# Altium schematic sheet CAN.SchDoc
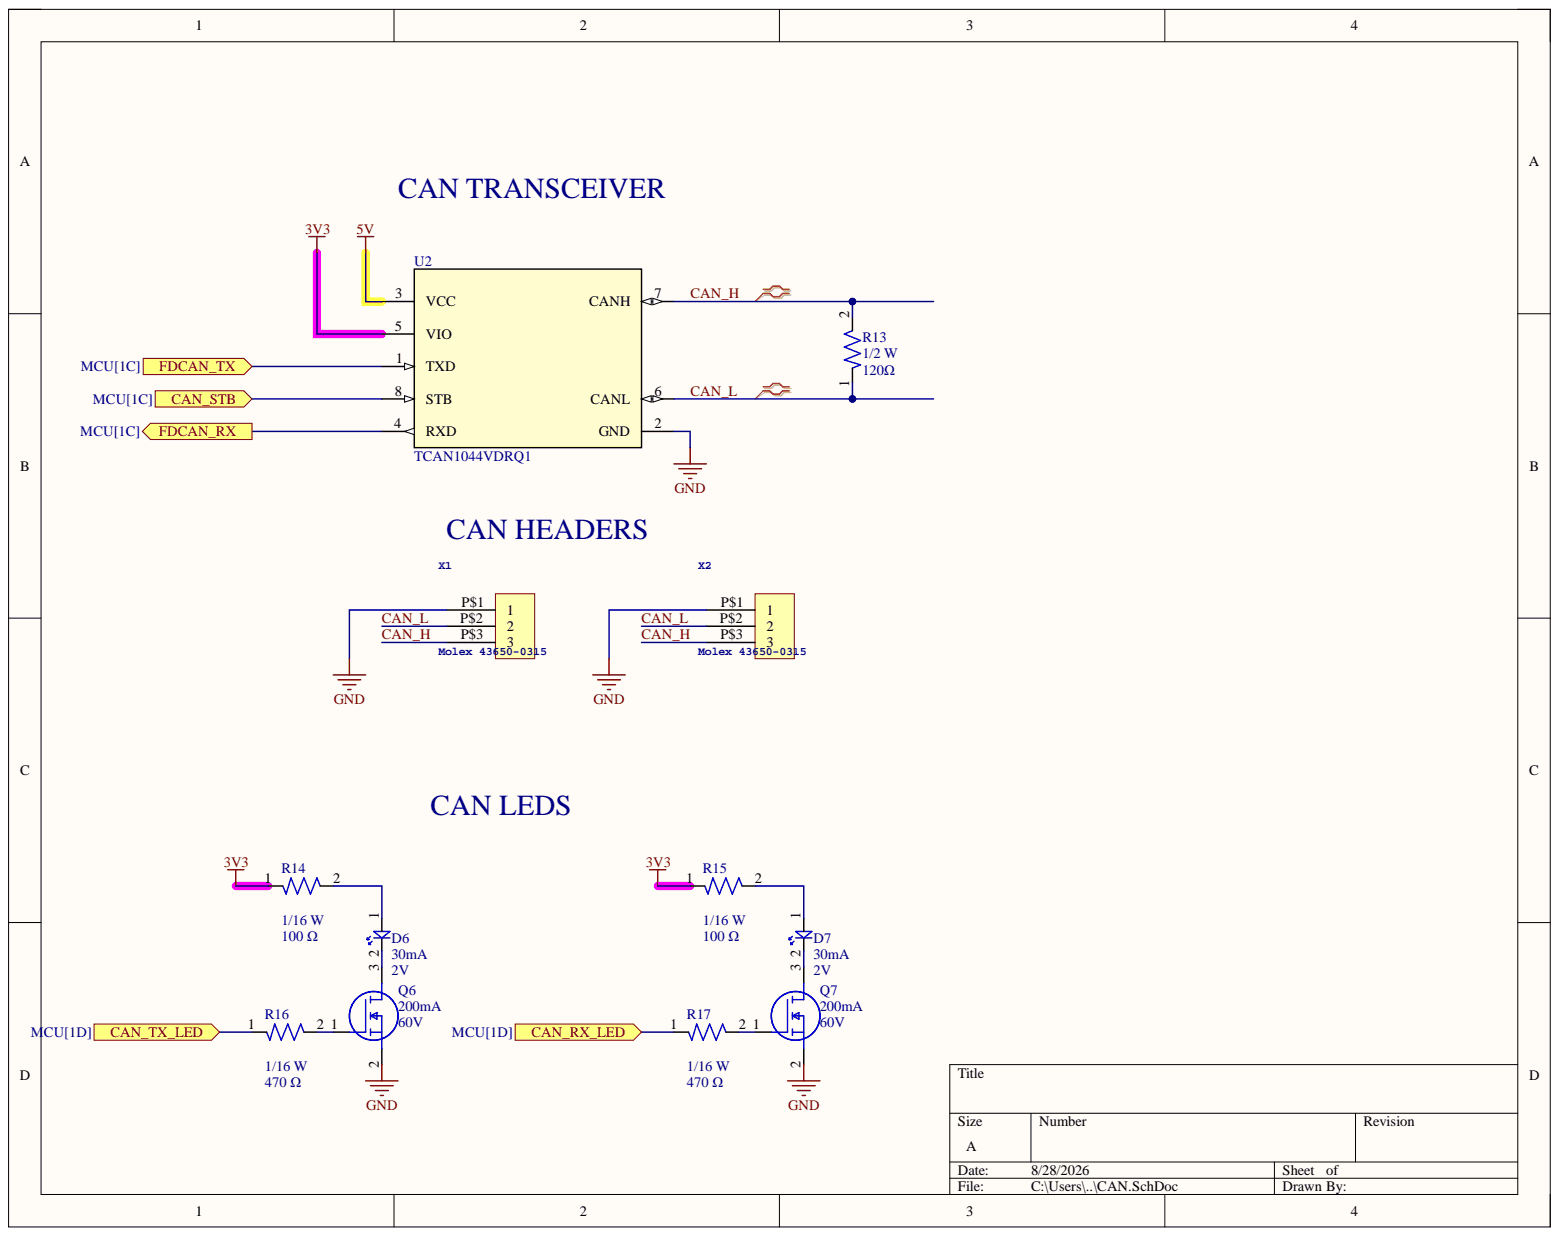
[diagram p0: CAN.SchDoc]

## Parts
D6  Forward Current: 30mA  [LED-0603]  (hidden comment: BL-HKC36G-AV-TRB)
D7  Forward Current: 30mA  [LED-0603]  (hidden comment: BL-HKC36G-AV-TRB)
Q6  Continuous Current: 200mA  Voltage: 60V  [IC-SOT23-3]  (hidden comment: T2N7002AK,LM)
Q7  Continuous Current: 200mA  Voltage: 60V  [IC-SOT23-3]  (hidden comment: T2N7002AK,LM)
R13  Resistance: 120Ω  Wattage: 1/2 W  [RES-1210]  (hidden comment: RC1210FR-07120RL)
R14  Wattage: 1/16 W  [RES-0402]  (hidden comment: RC0402FR-07100RL)
R15  Wattage: 1/16 W  [RES-0402]  (hidden comment: RC0402FR-07100RL)
R16  Wattage: 1/16 W  [RES-0402]  (hidden comment: RC0402FR-07470RL)
R17  Wattage: 1/16 W  [RES-0402]  (hidden comment: RC0402FR-07470RL)
U2  TCAN1044VDRQ1  [IC-8SOIC]
X1  Molex 43650-0315  [43650-0315]
X2  Molex 43650-0315  [43650-0315]

## Ports
CAN_RX_LED, CAN_STB, CAN_TX_LED, FDCAN_RX, FDCAN_TX

## Nets
3V3: R14.1 R15.1 U2.5(VIO)
5V: U2.3(VCC)
GND: Q6.2 Q7.2 U2.2(GND) X1.P$1 X2.P$1
CAN_H: R13.2 U2.7(CANH) X1.P$3 X2.P$3
CAN_L: R13.1 U2.6(CANL) X1.P$2 X2.P$2
CAN_RX_LED: R17.1 ; port CAN_RX_LED
CAN_STB: U2.8(STB) ; port CAN_STB
CAN_TX_LED: R16.1 ; port CAN_TX_LED
FDCAN_RX: U2.4(RXD) ; port FDCAN_RX
FDCAN_TX: U2.1(TXD) ; port FDCAN_TX
NetD6_1: D6.1 R14.2
NetD6_2: D6.2 Q6.3
NetD7_1: D7.1 R15.2
NetD7_2: D7.2 Q7.3
NetQ6_1: Q6.1 R16.2
NetQ7_1: Q7.1 R17.2

## Library data of the parts
D6 D7 = LED-0001: LED YELLOW CLEAR 0603 SMD; Forward Voltage: 2V; Component Kind: Standard; ComponentLink1Description: Datasheet; ComponentLink1URL: https://www.americanbrightled.com/pdffiles/led-components/smt/BL-HKC36G-AV-TRB.pdf; Library Name: EHW_27.IntLib
Q6 Q7 = NMOS-200MA-0001: MOSFET N-CH 60V 200MA SOT23; Component Kind: Standard; ComponentLink1Description: Datasheet; ComponentLink1URL: https://toshiba.semicon-storage.com/info/T2N7002AK_datasheet_en_20150401.pdf?did=29712&prodName=T2N7002AK; Library Name: EHW_27.IntLib
R13 = RES CAN TERM 1210: Component Kind: Standard; Library Name: EHW_27.IntLib
R14 R15 = RES 0402: Resistance: 100 Ω; Component Kind: Standard; Library Name: EHW_27.IntLib
R16 R17 = RES 0402: Resistance: 470 Ω; Component Kind: Standard; Library Name: EHW_27.IntLib
U2 = IC-CAN-0001: IC TRANSCEIVER 1/1 8SOIC; Component Kind: Standard; ComponentLink1Description: Datasheet; ComponentLink1URL: https://www.ti.com/lit/ds/symlink/tcan1044-q1.pdf?HQS=dis-dk-null-digikeymode-dsf-pf-null-wwe&ts=1728758303289&ref_url=https%253A%252F%252Fwww.ti.com%252Fgeneral%252Fdocs%252Fsuppproductinfo.tsp%253FdistId%253D10%2526gotoUrl%253Dhttps%253A%252F%252Fwww.ti.com%252Flit%252Fgpn%252Ftcan1044-q1; Library Name: EHW_27.IntLib
X1 X2 = HEADER-VERT-CAN-Micro-Fit Molex 3 Pin Vertical: DeviceSetName: HEADER_POS3_?; GateName_1: G$1; SymbolName_1: HEADER_POS3; Component Kind: Standard; Description: Molex 43650-0315; DeviceName: 43650-0315; LibraryName: con-molex-micro-fit-3_0_2; Package: 43650-0315; Library Name: EHW_27.IntLib
